# Altium schematic sheet Electrolux.SchDoc(Electrolux)
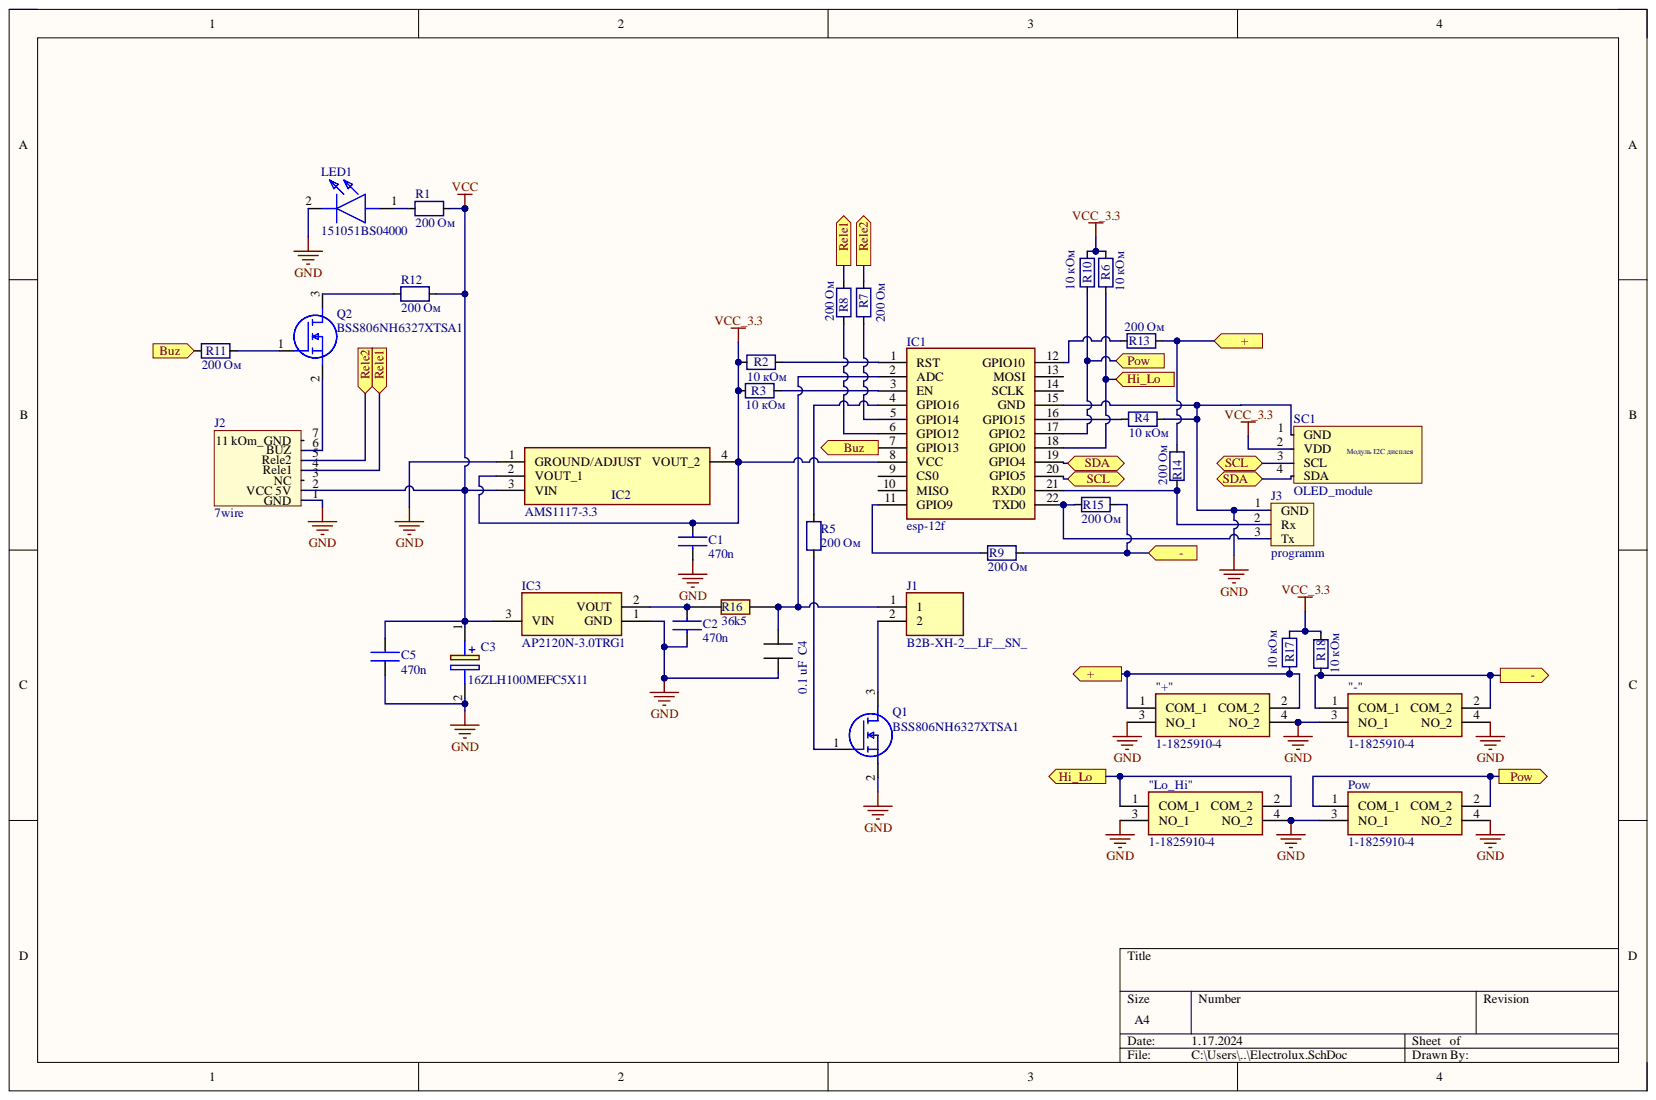
[diagram p0: Electrolux.SchDoc]

## Parts
"+"  1-1825910-4  [118259104]
"-"  1-1825910-4  [118259104]
"Lo_Hi"  1-1825910-4  [118259104]
C1  470n  [CAPC1005X55N]
C2  470n  [CAPC1005X55N]
C3  16ZLH100MEFC5X11  [CAPPRD200W50D550H1300]
C4  0.1 uF  [0402]
C5  470n  [CAPC1005X55N]
IC1  esp-12f  [esp12f]
IC2  AMS1117-3.3  [SOT229P700X180-4N]
IC3  AP2120N-3.0TRG1  [SOT96P240X120-3N]
J1  B2B-XH-2__LF__SN_  [B2BXH2LFSN]
J2  7wire  [DIP7x2.54]
J3  programm  [3x2.54]
LED1  151051BS04000  [151051BS04000]
Pow  1-1825910-4  [118259104]
Q1  BSS806NH6327XTSA1  [SOT95P240X110-3N]
Q2  BSS806NH6327XTSA1  [SOT95P240X110-3N]
R1  200 Ом  [0402]
R2  10 кОм  [0402]
R3  10 кОм  [0402]
R4  10 кОм  [0402]
R5  200 Ом  [0402]
R6  10 кОм  [0402]
R7  200 Ом  [0402]
R8  200 Ом  [0402]
R9  200 Ом  [0402]
R10  10 кОм  [0402]
R11  200 Ом  [0402]
R12  200 Ом  [0402]
R13  200 Ом  [0402]
R14  200 Ом  [0402]
R15  200 Ом  [0402]
R16  36k5  [RESC1005X40N]
R17  10 кОм  [0402]
R18  10 кОм  [0402]
SC1  OLED_module  [4x2.54]

## Ports
+, -, Buz, Hi_Lo, Pow, Rele1, Rele2, SCL, SDA

## Nets
GND: "+".3 "+".4 "-".3 "-".4 "Lo_Hi".3 "Lo_Hi".4 C1.1 C2.1 C3.2 C4.1 C5.1 IC1.15(GND) IC2.1(GROUND/ADJUST) IC3.1 J2.1(GND) J3.1 LED1.2 Pow.3(NO_1) Pow.4(NO_2) Q1.2 R4.2 SC1.1(GND)
VCC: C3.1 C5.2 IC2.3(VIN) IC3.3 J2.2(VCC_5V) R1.2 R12.2
VCC_3.3: C1.2 IC1.8(VCC) IC2.2(VOUT_1) IC2.4(VOUT_2) R2.1 R3.1 R6.2 R10.2 R17.2 R18.2 SC1.2(VDD)
Buz: IC1.7(GPIO13) R11.1 ; port Buz
Hi_Lo: "Lo_Hi".1 "Lo_Hi".2 IC1.18(GPIO0) R6.1 ; port Hi_Lo
Pow: IC1.17(GPIO2) Pow.1(COM_1) Pow.2(COM_2) R10.1 ; port Pow
Rele1: J2.4(Rele1) R8.2 ; port Rele1
Rele2: J2.5(Rele2) R7.2 ; port Rele2
SCL: IC1.20(GPIO5) SC1.3(SCL) ; port SCL
SDA: IC1.19(GPIO4) SC1.4(SDA) ; port SDA
Net"+"_1: "+".1 "+".2 R13.2 R14.2 R17.1
Net"-"_1: "-".1 "-".2 R9.2 R15.2 R18.1
NetC2_2: C2.2 IC3.2 R16.1
NetC4_2: C4.2 IC1.2(ADC) J1.1 R16.2
NetIC1_1: IC1.1(RST) R2.2
NetIC1_3: IC1.3(EN) R3.2
NetIC1_4: IC1.4(GPIO16) R5.1
NetIC1_5: IC1.5(GPIO14) R7.1
NetIC1_6: IC1.6(GPIO12) R8.1
NetIC1_11: IC1.11(GPIO9) R9.1
NetIC1_12: IC1.12(GPIO10) R13.1
NetIC1_16: IC1.16(GPIO15) R4.1
NetIC1_21: IC1.21(RXD0) J3.2 R14.1
NetIC1_22: IC1.22(TXD0) J3.3 R15.1
NetJ1_2: J1.2 Q1.3
NetJ2_6: J2.6(BUZ) Q2.2
NetLED1_1: LED1.1 R1.1
NetQ1_1: Q1.1 R5.2
NetQ2_1: Q2.1 R11.2
NetQ2_3: Q2.3 R12.1

Not connected: IC1 9(CS0) 10(MISO) 13(MOSI) 14(SCLK); J2 3(NC) 7(11_kOm_GND)

## Library data of the parts
"+" "-" "Lo_Hi" Pow = 1-1825910-4: Switch; Manufacturer_Name: TE Connectivity; Arrow Part Number: 1-1825910-4; Arrow Price/Stock: https://www.arrow.com/en/products/1-1825910-4/te-connectivity?region=europe; Component Kind: Standard; Datasheet Link: https://www.te.com/commerce/DocumentDelivery/DDEController?Action=showdoc&DocId=Customer+Drawing%7F1825910%7FC10%7Fpdf%7FEnglish%7FENG_CD_1825910_C10.pdf%7F1-1825910-4; Height: 7.7mm; Manufacturer_Part_Number: 1-1825910-4; Mouser Part Number: 506-1-1825910-4; Mouser Price/Stock: https://www.mouser.co.uk/ProductDetail/TE-Connectivity-PB/1-1825910-4?qs=pffCcXpkxLwYJJd3DYaJ7Q%3D%3D; Library Name: Библиотека элементов.SchLib
C1 C2 C5 = GRM155R71A474KE01J: Capacitor; Manufacturer_Name: Murata Electronics; Arrow Part Number: GRM155R71A474KE01J; Component Kind: Standard; Datasheet Link: http://www.murata.com/~/media/webrenewal/support/library/catalog/products/capacitor/mlcc/c02e.pdf; Height: 0.55mm; Manufacturer_Part_Number: GRM155R71A474KE01J; Mouser Part Number: 81-GRM155R71A474KE1J; Mouser Price/Stock: https://www.mouser.co.uk/ProductDetail/Murata-Electronics/GRM155R71A474KE01J?qs=jHkklCh7amg%2FxD2nsZqThg%3D%3D; Library Name: Библиотека элементов.SchLib
C3 = 16ZLH100MEFC5X11: Capacitor Polarised; Manufacturer_Name: Rubycon; Arrow Part Number: 16ZLH100MEFC5X11; Arrow Price/Stock: https://www.arrow.com/en/products/16zlh100mefc5x11/rubycon?region=nac; Component Kind: Standard; Datasheet Link: https://componentsearchengine.com/Datasheets/2/16ZLH100MEFC5X11.pdf; Height: 13mm; Manufacturer_Part_Number: 16ZLH100MEFC5X11; Mouser Part Number: 232-16ZLH100MEFC5X11; Mouser Price/Stock: https://www.mouser.co.uk/ProductDetail/Rubycon/16ZLH100MEFC5X11?qs=T3oQrply3y%252BqHkLqRu3b6g%3D%3D
C4 = 0.1 uF: 0402; Manufaktur: Murata; ACU: 10%; Dielectric: X7R; MARC: GRM155R71H104KE14#; U: 50 V; CAP: 0.1 uF; Component Kind: Standard; DIM: 0402; Library Name: Библиотека элементов.SchLib
IC1 = esp-12f: Integrated Circuit; Manufacturer_Name: Ai-Thinker; Component Kind: Standard; Datasheet Link: https://docs.ai-thinker.com/_media/esp8266/docs/esp-12f_product_specification_en.pdf; Height: 3.1mm; Manufacturer_Part_Number: esp-12f; Library Name: Библиотека элементов.SchLib
IC2 = AMS1117-3.3: Integrated Circuit; Manufacturer_Name: ams; Component Kind: Standard; Datasheet Link: http://www.advanced-monolithic.com/pdf/ds1117.pdf; Height: 1.8mm; Manufacturer_Part_Number: AMS1117-3.3; Library Name: Библиотека элементов.SchLib
IC3 = AP2120N-3.0TRG1: Integrated Circuit; Manufacturer_Name: Diodes Incorporated; Arrow Part Number: AP2120N-3.0TRG1; Arrow Price/Stock: https://www.arrow.com/en/products/ap2120n-3.0trg1/diodes-incorporated?region=nac; Component Kind: Standard; Datasheet Link: https://www.diodes.com/assets/Datasheets/AP2120.pdf; Height: 1.2mm; Manufacturer_Part_Number: AP2120N-3.0TRG1; Mouser Part Number: 621-AP2120N-3.0TRG1; Mouser Price/Stock: https://www.mouser.co.uk/ProductDetail/Diodes-Incorporated/AP2120N-3.0TRG1?qs=x6A8l6qLYDDoffGIuz1O7A%3D%3D; Library Name: Библиотека элементов.SchLib
J1 = B2B-XH-2__LF__SN_: Connector; Manufacturer_Name: JST (JAPAN SOLDERLESS TERMINALS); Manufacturer_Part_Number: B2B-XH-2 (LF)(SN); Arrow Part Number: B2B-XH-2 (LF)(SN); Arrow Price/Stock: https://www.arrow.com/en/products/b2b-xh-2-lf-sn/jst-manufacturing?region=europe; Component Kind: Standard; Datasheet Link: http://uk.rs-online.com/web/p/products/1755297; Height: 7mm; Library Name: Библиотека элементов.SchLib
LED1 = 151051BS04000: LED; Manufacturer_Name: Wurth Elektronik; Component Kind: Standard; Datasheet Link: https://katalog.we-online.de/led/datasheet/151051BS04000.pdf; Height: 8.95mm; Manufacturer_Part_Number: 151051BS04000; Mouser Part Number: 710-151051BS04000; Mouser Price/Stock: https://www.mouser.co.uk/ProductDetail/Wurth-Elektronik/151051BS04000?qs=LlUlMxKIyB3OjuZOlqaOjg%3D%3D; Library Name: Библиотека элементов.SchLib
Q1 Q2 = BSS806NH6327XTSA1: MOSFET (N-Channel); Manufacturer_Name: Infineon; Arrow Part Number: BSS806NH6327XTSA1; Arrow Price/Stock: https://www.arrow.com/en/products/bss806nh6327xtsa1/infineon-technologies-ag?region=europe; Component Kind: Standard; Datasheet Link: https://www.infineon.com/dgdl/Infineon-BSS806N-DS-v02_03-en.pdf?fileId=db3a304330f686060131185f0553451c; Height: 1.1mm; Manufacturer_Part_Number: BSS806NH6327XTSA1; Mouser Part Number: 726-BSS806NH6327; Mouser Price/Stock: https://www.mouser.co.uk/ProductDetail/Infineon-Technologies/BSS806NH6327XTSA1?qs=K00xGehIljvbwLwhvALzag%3D%3D; Library Name: Библиотека элементов.SchLib
R1 R5 R7-R9 R11-R15 = 200 Ом: 0402; Component Kind: Standard; Res: 200 Ом; Library Name: Библиотека элементов.SchLib
R2-R4 R6 R10 R17 R18 = 10 кОм: 0402; Component Kind: Standard; Res: 10 кОм; Library Name: Библиотека элементов.SchLib
R16 = CRCW040236K5FKEDHP: Resistor; Manufacturer_Name: Vishay; Component Kind: Standard; Datasheet Link: http://www.vishay.com/docs/20035/dcrcwe3.pdf; Height: 0.4mm; Manufacturer_Part_Number: CRCW040236K5FKEDHP; Library Name: Библиотека элементов.SchLib
SC1 = OLED_module: i2c display oled; Component Kind: Standard; Library Name: Библиотека элементов.SchLib
J2 = 7wire: Component Kind: Standard; Library Name: Библиотека элементов.SchLib
J3 = programm: Component Kind: Standard; Library Name: Библиотека элементов.SchLib
